# Altium schematic sheet ETH&&USB.SchDoc(ETH&&USB)
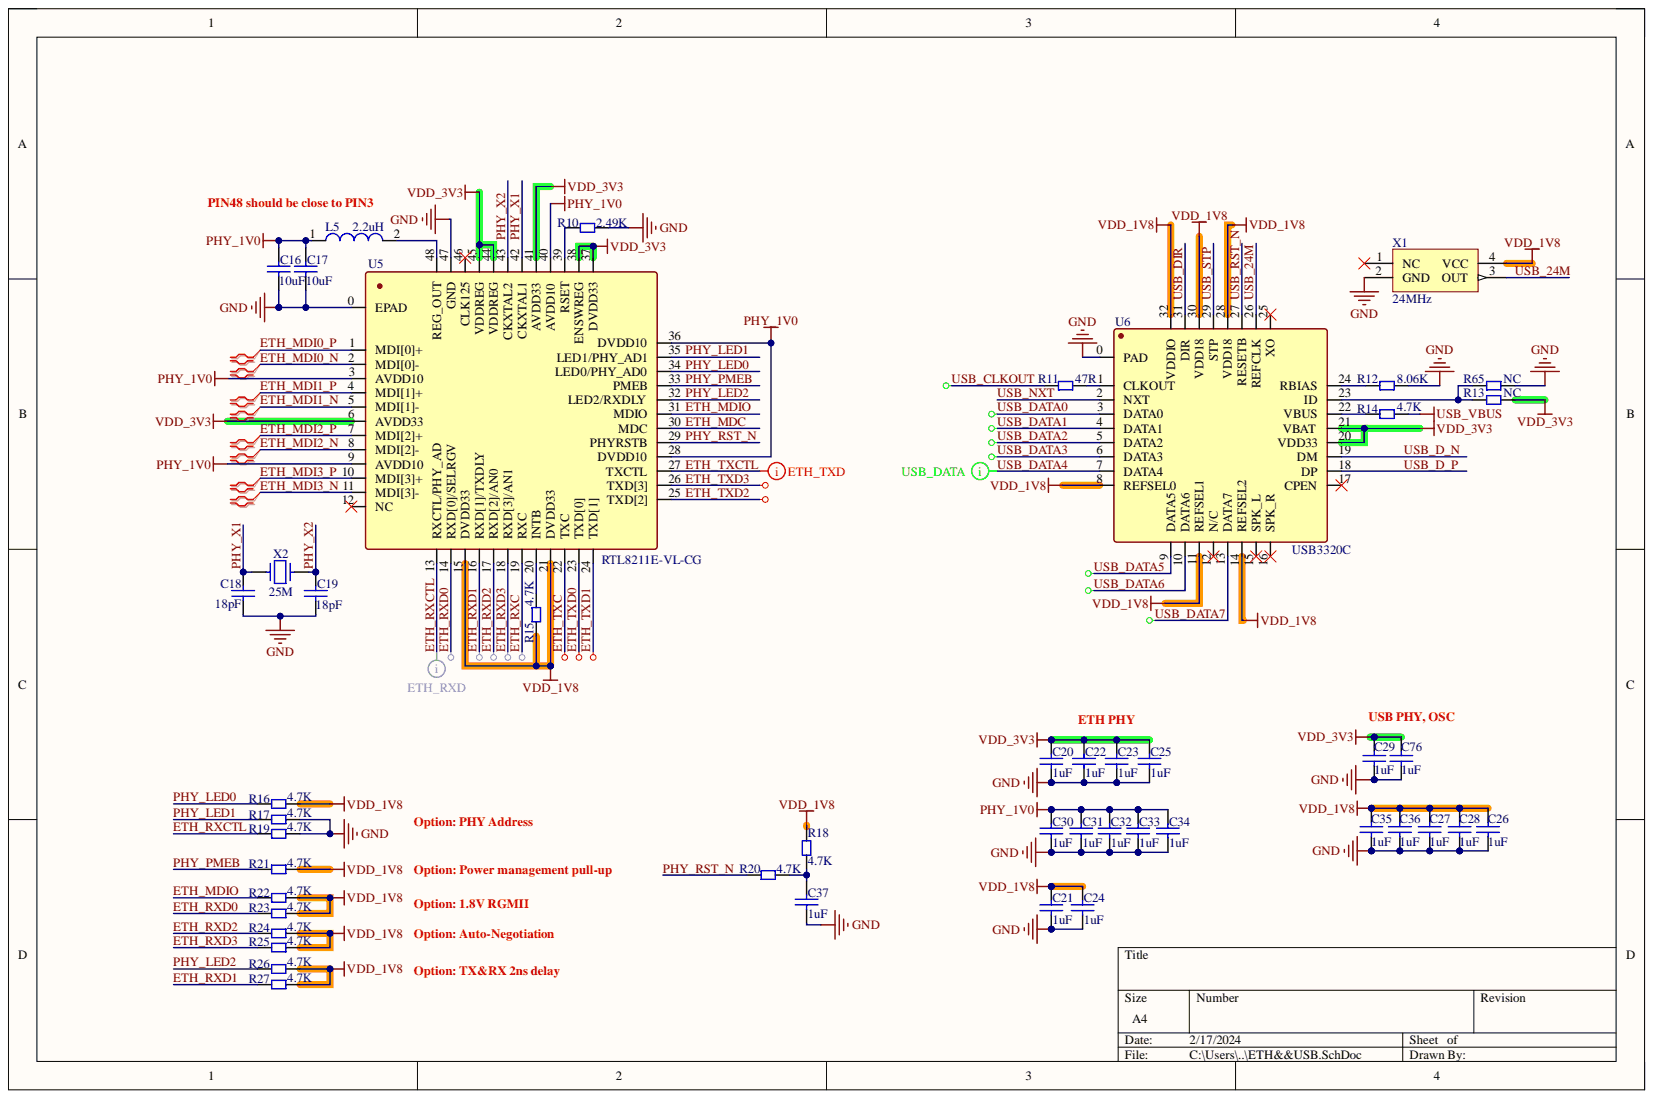
[diagram p0: ETH&&USB.SchDoc]

## Parts
C16  10uF  [C0402]
C17  10uF  [C0402]
C18  18pF  [C0402]
C19  18pF  [C0402]
C20  1uF  [C0402]
C21  1uF  [C0402]
C22  1uF  [C0402]
C23  1uF  [C0402]
C24  1uF  [C0402]
C25  1uF  [C0402]
C26  1uF  [C0402]
C27  1uF  [C0402]
C28  1uF  [C0402]
C29  1uF  [C0402]
C30  1uF  [C0402]
C31  1uF  [C0402]
C32  1uF  [C0402]
C33  1uF  [C0402]
C34  1uF  [C0402]
C35  1uF  [C0402]
C36  1uF  [C0402]
C37  1uF  [C0402]
C76  1uF  [C0402]
L5  2.2uH  [SMD-2520]
R10  2.49K  [R0402]
R11  47R  [R0402]
R12  8.06K  [R0402]
R13  NC  [R0402]
R14  4.7K  [R0402]
R15  4.7K  [R0402]
R16  4.7K  [R0402]
R17  4.7K  [R0402]
R18  4.7K  [R0402]
R19  4.7K  [R0402]
R20  4.7K  [R0402]
R21  4.7K  [R0402]
R22  4.7K  [R0402]
R23  4.7K  [R0402]
R24  4.7K  [R0402]
R25  4.7K  [R0402]
R26  4.7K  [R0402]
R27  4.7K  [R0402]
R65  NC  [R0402]
U5  RTL8211E-VL-CG  [QFN-48]
U6  USB3320C  [QFN-32]
X1  24MHz  [SMD-3225_4P]
X2  25M  [SMD-5032_2P]

## Nets
GND: C16.1 C17.1 C18.2 C19.2 C20.1 C21.1 C22.1 C23.1 C24.1 C25.1 C26.1 C27.1 C28.1 C29.1 C30.1 C31.1 C32.1 C33.1 C34.1 C35.1 C36.1 C37.1 C76.1 R10.2 R12.2 R17.2 R19.2 R65.2 U5.0(EPAD) U5.47(GND) U6.0(PAD) X1.2(GND)
PHY_1V0: C16.2 C17.2 C30.2 C31.2 C32.2 C33.2 C34.2 L5.1 U5.3(AVDD10) U5.9(AVDD10) U5.28(DVDD10) U5.36(DVDD10) U5.40(AVDD10)
USB_VBUS: R14.2
VDD_1V8: C21.2 C24.2 C26.2 C27.2 C28.2 C35.2 C36.2 R15.1 R16.2 R18.2 R21.2 R22.2 R23.2 R24.2 R25.2 R26.2 R27.2 U5.15(DVDD33) U5.21(DVDD33) U6.8(REFSEL0) U6.11(REFSEL1) U6.14(REFSEL2) U6.28(VDD18) U6.30(VDD18) U6.32(VDDIO) X1.4(VCC)
VDD_3V3: C20.2 C22.2 C23.2 C25.2 C29.2 C76.2 R13.2 U5.6(AVDD33) U5.37(DVDD33) U5.38(ENSWREG) U5.41(AVDD33) U5.44(VDDREG) U5.45(VDDREG) U6.20(VDD33) U6.21(VBAT)
ETH_MDC: U5.30(MDC)
ETH_MDI0_N: U5.2(MDI[0]-)
ETH_MDI0_P: U5.1(MDI[0]+)
ETH_MDI1_N: U5.5(MDI[1]-)
ETH_MDI1_P: U5.4(MDI[1]+)
ETH_MDI2_N: U5.8(MDI[2]-)
ETH_MDI2_P: U5.7(MDI[2]+)
ETH_MDI3_N: U5.11(MDI[3]-)
ETH_MDI3_P: U5.10(MDI[3]+)
ETH_MDIO: R22.1 U5.31(MDIO)
ETH_RXC: U5.19(RXC)
ETH_RXCTL: R19.1 U5.13(RXCTL/PHY_AD)
ETH_RXD0: R23.1 U5.14(RXD[0]/SELRGV)
ETH_RXD1: R27.1 U5.16(RXD[1]/TXDLY)
ETH_RXD2: R24.1 U5.17(RXD[2]/AN0)
ETH_RXD3: R25.1 U5.18(RXD[3]/AN1)
ETH_TXC: U5.22(TXC)
ETH_TXCTL: U5.27(TXCTL)
ETH_TXD0: U5.23(TXD[0])
ETH_TXD1: U5.24(TXD[1])
ETH_TXD2: U5.25(TXD[2])
ETH_TXD3: U5.26(TXD[3])
PHY_LED0: R16.1 U5.34(LED0/PHY_AD0)
PHY_LED1: R17.1 U5.35(LED1/PHY_AD1)
PHY_LED2: R26.1 U5.32(LED2/RXDLY)
PHY_PMEB: R21.1 U5.33(PMEB)
PHY_RST_N: R20.1 U5.29(PHYRSTB)
PHY_X1: C18.1 U5.42(CKXTAL1) X2.2
PHY_X2: C19.1 U5.43(CKXTAL2) X2.1
USB_24M: U6.26(REFCLK) X1.3(OUT)
USB_CLKOUT: R11.1
USB_D_N: U6.19(DM)
USB_D_P: U6.18(DP)
USB_DATA0: U6.3(DATA0)
USB_DATA1: U6.4(DATA1)
USB_DATA2: U6.5(DATA2)
USB_DATA3: U6.6(DATA3)
USB_DATA4: U6.7(DATA4)
USB_DATA5: U6.9(DATA5)
USB_DATA6: U6.10(DATA6)
USB_DATA7: U6.13(DATA7)
USB_DIR: U6.31(DIR)
USB_NXT: U6.2(NXT)
USB_RST_N: U6.27(RESETB)
USB_STP: U6.29(STP)
NetC37_2: C37.2 R18.1 R20.2
NetL5_2: L5.2 U5.48(REG_OUT)
NetR10_1: R10.1 U5.39(RSET)
NetR11_2: R11.2 U6.1(CLKOUT)
NetR12_1: R12.1 U6.24(RBIAS)
NetR13_1: R13.1 R65.1 U6.23(ID)
NetR14_1: R14.1 U6.22(VBUS)
NetR15_2: R15.2 U5.20(INTB)

Not connected: U5 12(NC) 46(CLK125); U6 12(N/C) 15(SPK_L) 16(SPK_R) 17(CPEN) 25(XO); X1 1(NC)

## Library data of the parts
C16-C37 C76 = Cap_NP: CAP no polarity; Component Kind: Standard; Library Name: Common.SchLib
L5 = Inductor: Component Kind: Standard; Description: Inductor; Library Name: Common.SchLib
R10-R27 R65 = Res: Component Kind: Standard; Description: Res; Library Name: Common.SchLib
U5 = RTL8211E-VB-CG: ETH phy; Component Kind: Standard; Library Name: ZYNQ_SDR.SCHLIB
U6 = USB3320C: USB2.0 phy; Component Kind: Standard; Library Name: ZYNQ_SDR.SCHLIB
X1 = XTAL-Active: Oscillator; Component Kind: Standard; Library Name: Common.SchLib
X2 = XTAL-Passive: Crystal; Component Kind: Standard; Library Name: Common.SchLib
